# Altium schematic sheet backplane.SchDoc
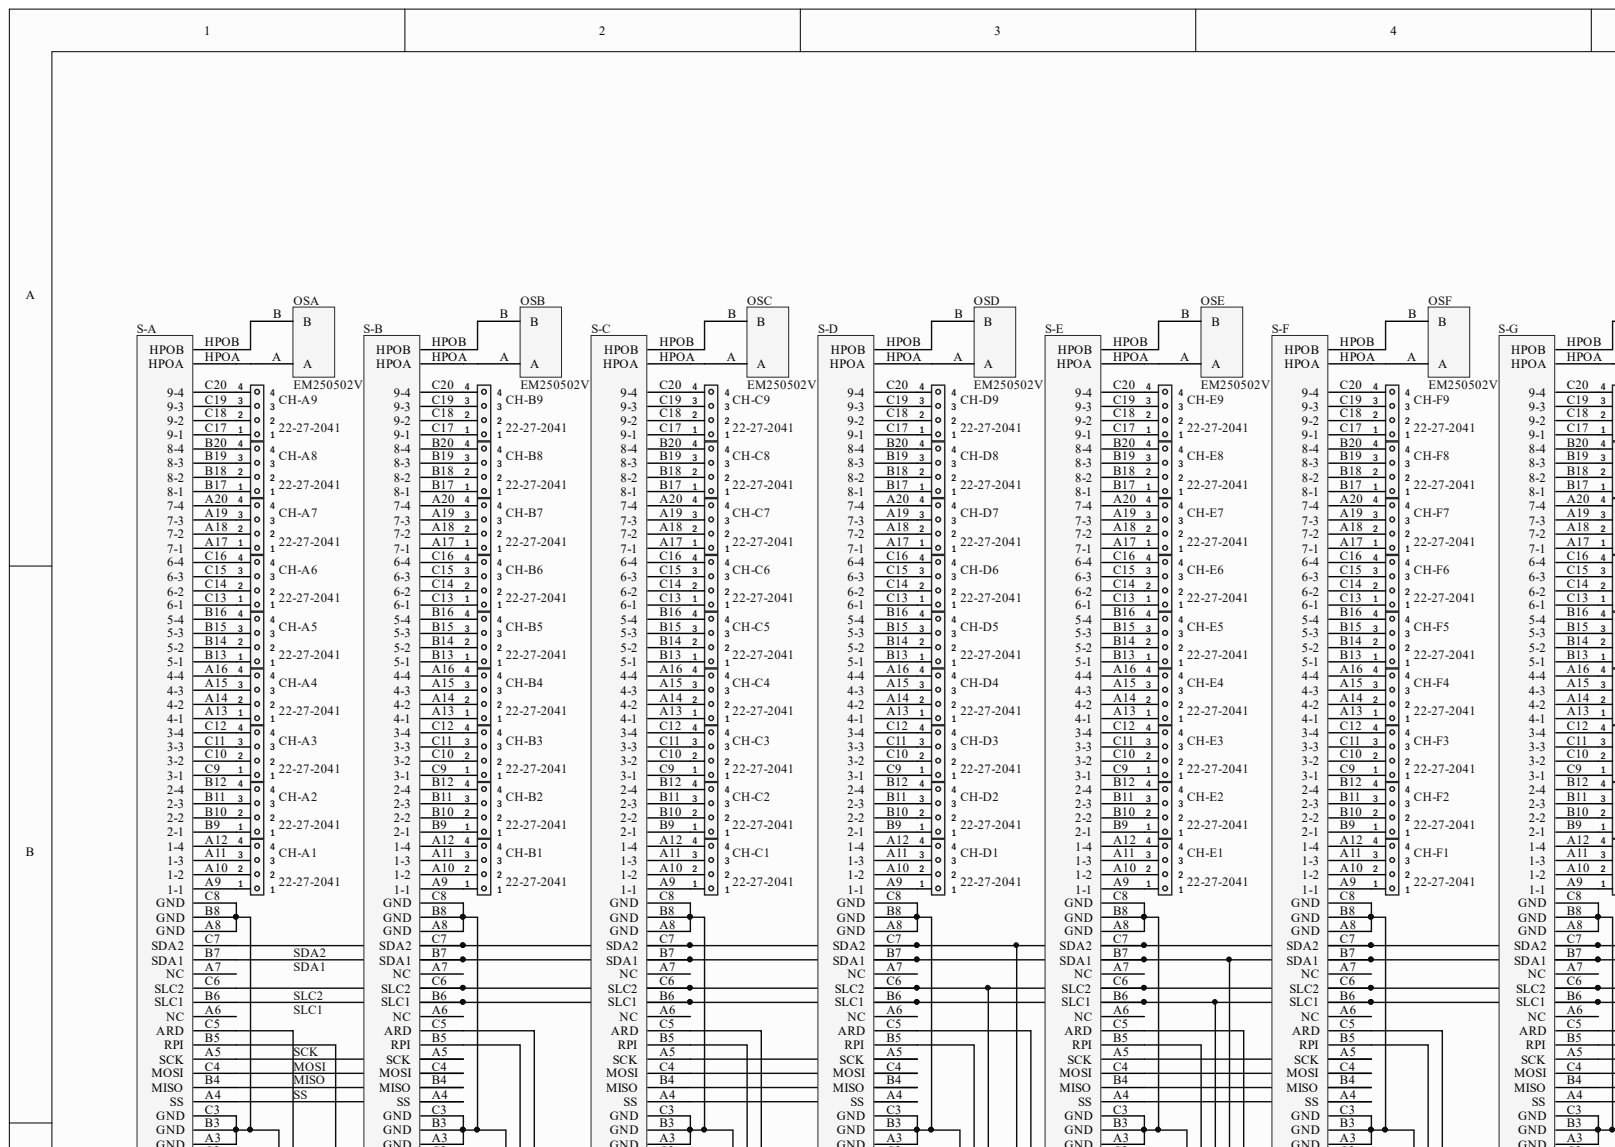
[diagram p0: backplane.SchDoc, top left]
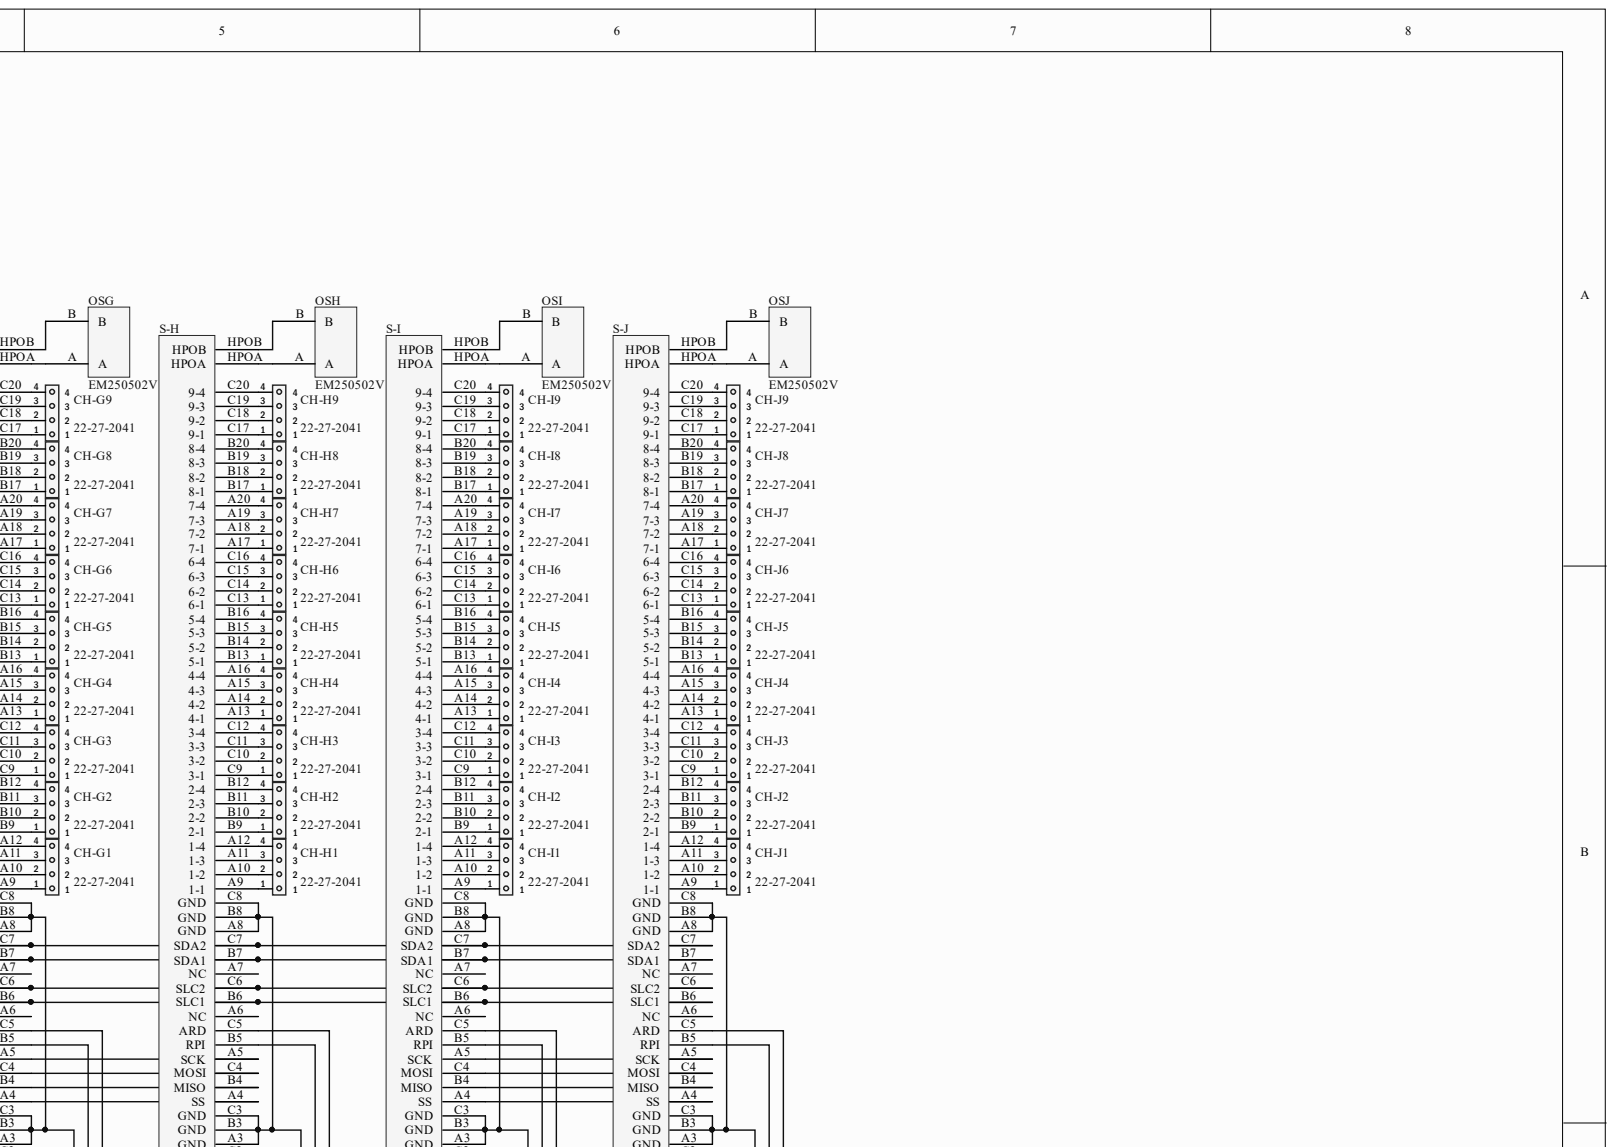
[diagram p1: backplane.SchDoc, top right]
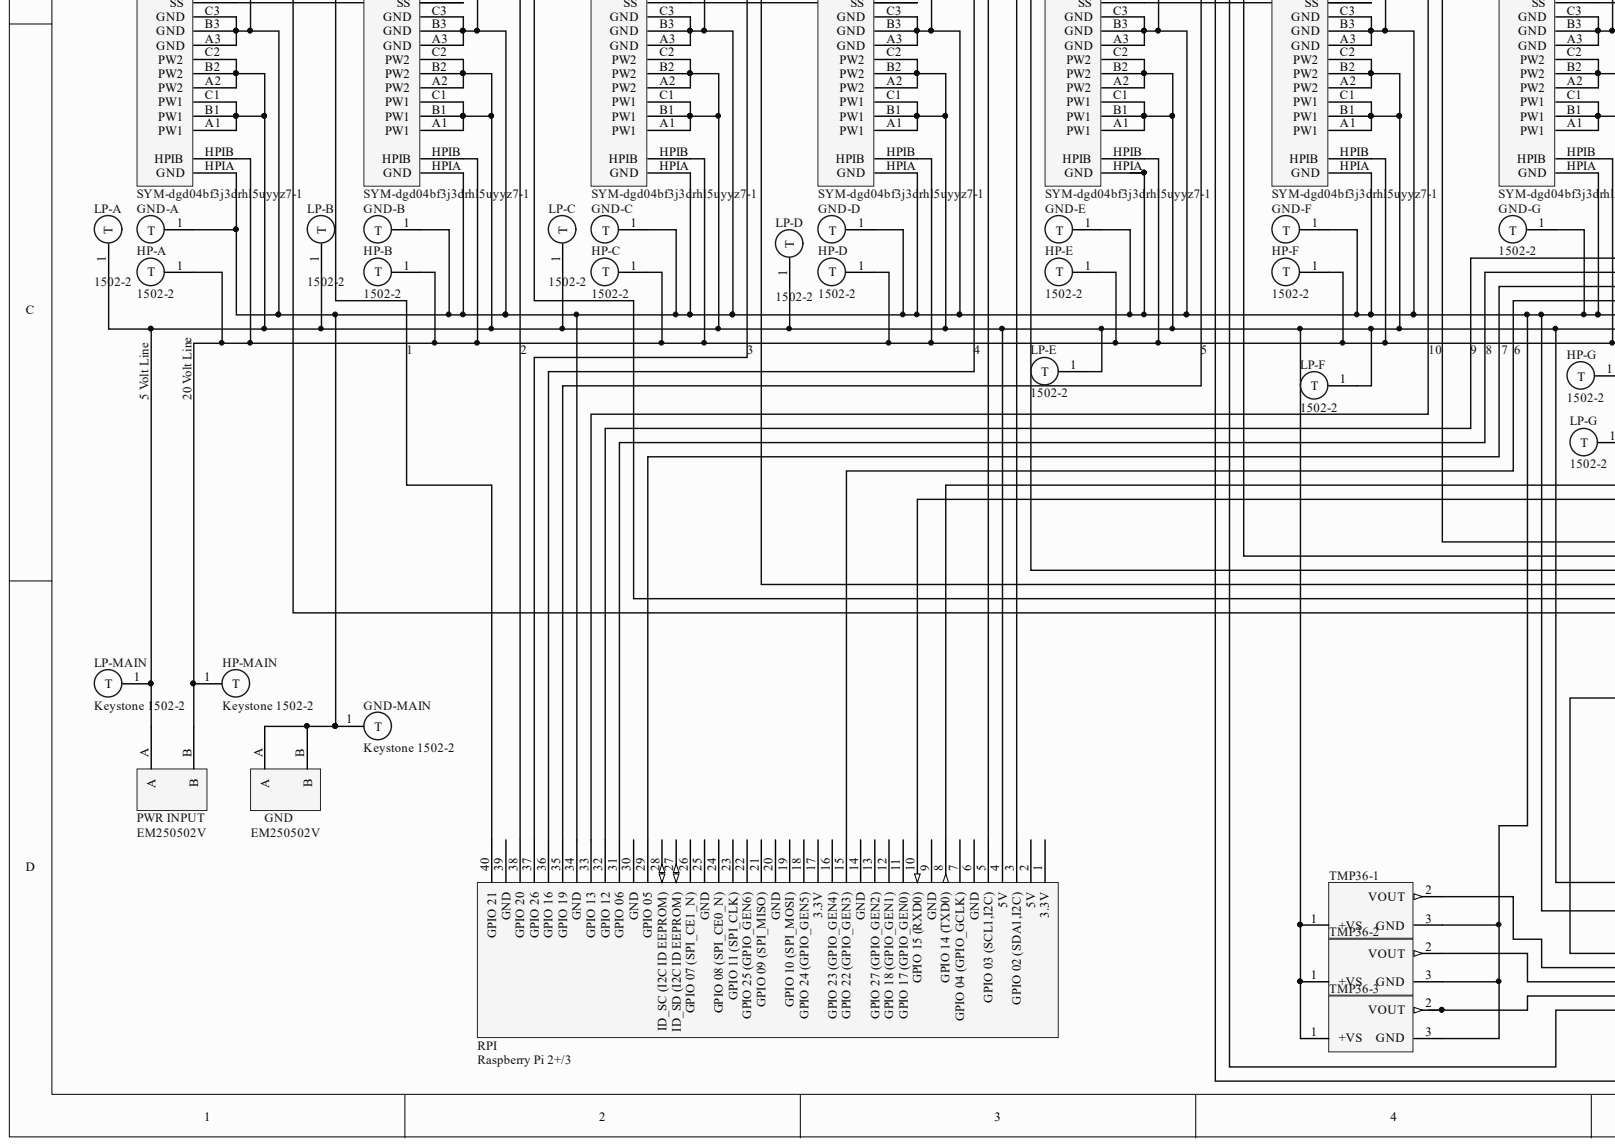
[diagram p2: backplane.SchDoc, bottom left]
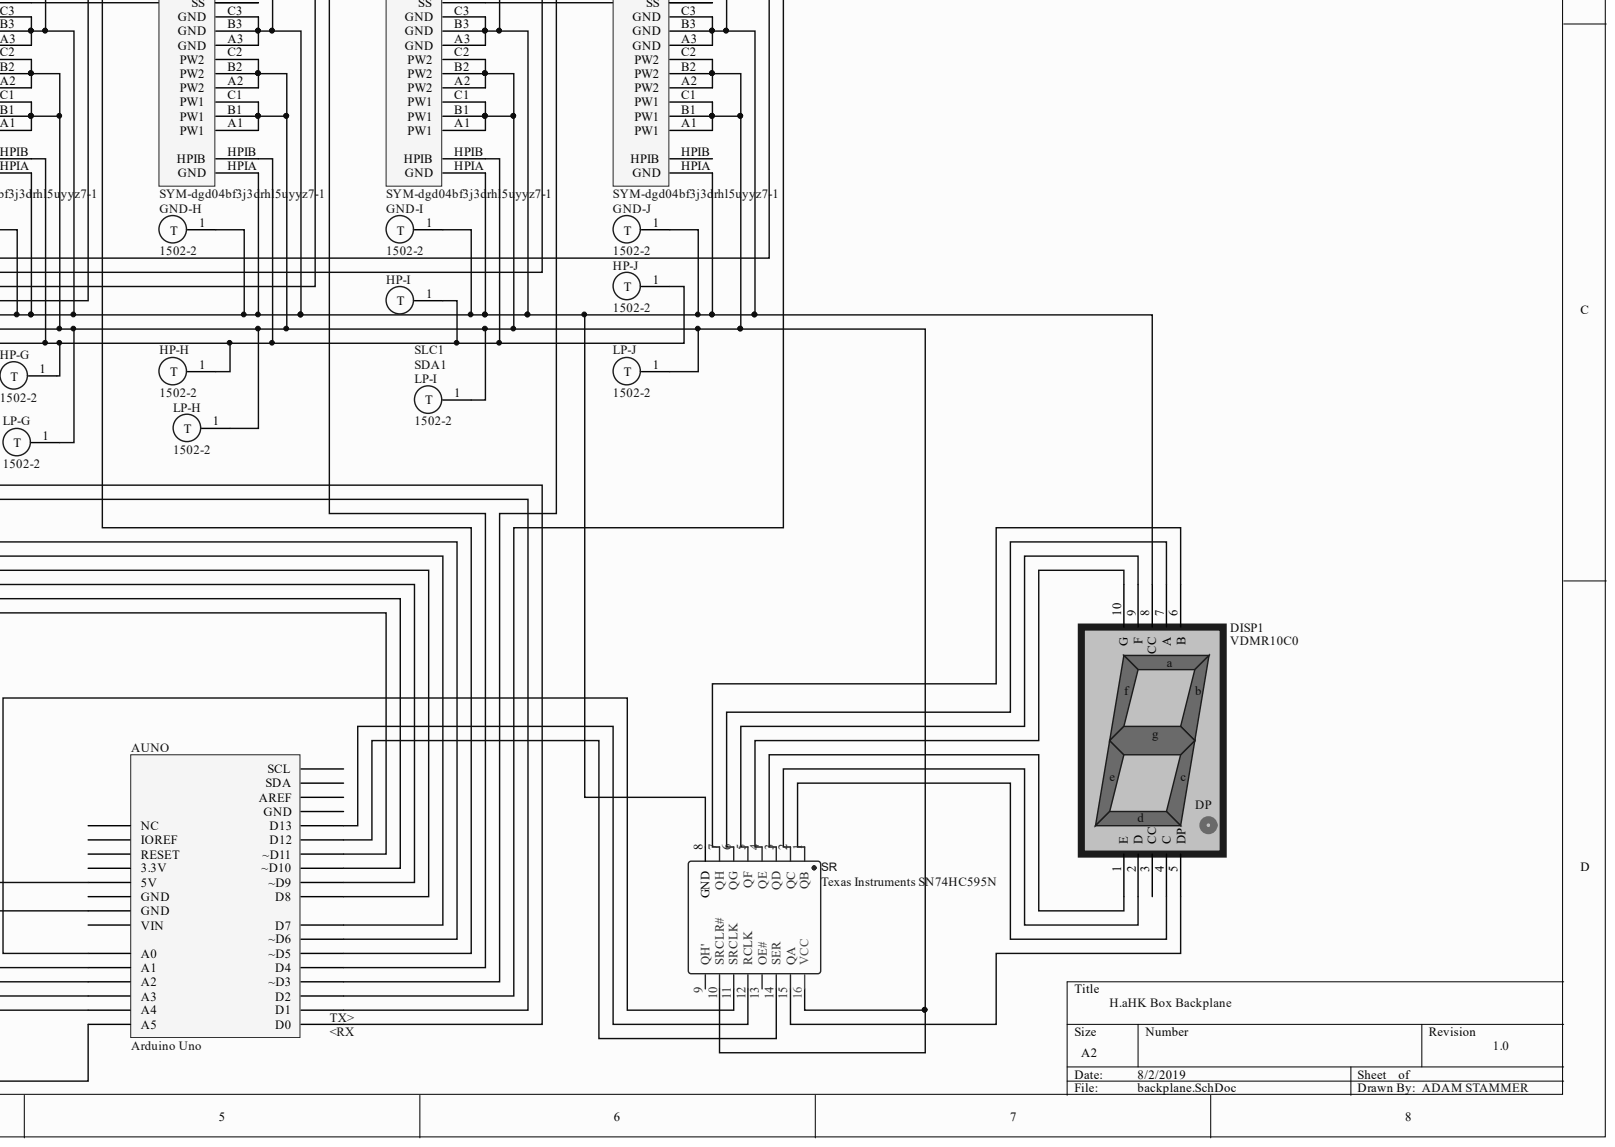
[diagram p3: backplane.SchDoc, bottom right]

## Parts
AUNO  Arduino Uno  [PCB-5e3n8s0ey5jqr2f9nncc-1]
CH-A1  22-27-2041  [PCB-kaiyohh0lgufhypq6v61-1]
CH-A2  22-27-2041  [PCB-kaiyohh0lgufhypq6v61-1]
CH-A3  22-27-2041  [PCB-kaiyohh0lgufhypq6v61-1]
CH-A4  22-27-2041  [PCB-kaiyohh0lgufhypq6v61-1]
CH-A5  22-27-2041  [PCB-kaiyohh0lgufhypq6v61-1]
CH-A6  22-27-2041  [PCB-kaiyohh0lgufhypq6v61-1]
CH-A7  22-27-2041  [PCB-kaiyohh0lgufhypq6v61-1]
CH-A8  22-27-2041  [PCB-kaiyohh0lgufhypq6v61-1]
CH-A9  22-27-2041  [PCB-kaiyohh0lgufhypq6v61-1]
CH-B1  22-27-2041  [PCB-kaiyohh0lgufhypq6v61-1]
CH-B2  22-27-2041  [PCB-kaiyohh0lgufhypq6v61-1]
CH-B3  22-27-2041  [PCB-kaiyohh0lgufhypq6v61-1]
CH-B4  22-27-2041  [PCB-kaiyohh0lgufhypq6v61-1]
CH-B5  22-27-2041  [PCB-kaiyohh0lgufhypq6v61-1]
CH-B6  22-27-2041  [PCB-kaiyohh0lgufhypq6v61-1]
CH-B7  22-27-2041  [PCB-kaiyohh0lgufhypq6v61-1]
CH-B8  22-27-2041  [PCB-kaiyohh0lgufhypq6v61-1]
CH-B9  22-27-2041  [PCB-kaiyohh0lgufhypq6v61-1]
CH-C1  22-27-2041  [PCB-kaiyohh0lgufhypq6v61-1]
CH-C2  22-27-2041  [PCB-kaiyohh0lgufhypq6v61-1]
CH-C3  22-27-2041  [PCB-kaiyohh0lgufhypq6v61-1]
CH-C4  22-27-2041  [PCB-kaiyohh0lgufhypq6v61-1]
CH-C5  22-27-2041  [PCB-kaiyohh0lgufhypq6v61-1]
CH-C6  22-27-2041  [PCB-kaiyohh0lgufhypq6v61-1]
CH-C7  22-27-2041  [PCB-kaiyohh0lgufhypq6v61-1]
CH-C8  22-27-2041  [PCB-kaiyohh0lgufhypq6v61-1]
CH-C9  22-27-2041  [PCB-kaiyohh0lgufhypq6v61-1]
CH-D1  22-27-2041  [PCB-kaiyohh0lgufhypq6v61-1]
CH-D2  22-27-2041  [PCB-kaiyohh0lgufhypq6v61-1]
CH-D3  22-27-2041  [PCB-kaiyohh0lgufhypq6v61-1]
CH-D4  22-27-2041  [PCB-kaiyohh0lgufhypq6v61-1]
CH-D5  22-27-2041  [PCB-kaiyohh0lgufhypq6v61-1]
CH-D6  22-27-2041  [PCB-kaiyohh0lgufhypq6v61-1]
CH-D7  22-27-2041  [PCB-kaiyohh0lgufhypq6v61-1]
CH-D8  22-27-2041  [PCB-kaiyohh0lgufhypq6v61-1]
CH-D9  22-27-2041  [PCB-kaiyohh0lgufhypq6v61-1]
CH-E1  22-27-2041  [PCB-kaiyohh0lgufhypq6v61-1]
CH-E2  22-27-2041  [PCB-kaiyohh0lgufhypq6v61-1]
CH-E3  22-27-2041  [PCB-kaiyohh0lgufhypq6v61-1]
CH-E4  22-27-2041  [PCB-kaiyohh0lgufhypq6v61-1]
CH-E5  22-27-2041  [PCB-kaiyohh0lgufhypq6v61-1]
CH-E6  22-27-2041  [PCB-kaiyohh0lgufhypq6v61-1]
CH-E7  22-27-2041  [PCB-kaiyohh0lgufhypq6v61-1]
CH-E8  22-27-2041  [PCB-kaiyohh0lgufhypq6v61-1]
CH-E9  22-27-2041  [PCB-kaiyohh0lgufhypq6v61-1]
CH-F1  22-27-2041  [PCB-kaiyohh0lgufhypq6v61-1]
CH-F2  22-27-2041  [PCB-kaiyohh0lgufhypq6v61-1]
CH-F3  22-27-2041  [PCB-kaiyohh0lgufhypq6v61-1]
CH-F4  22-27-2041  [PCB-kaiyohh0lgufhypq6v61-1]
CH-F5  22-27-2041  [PCB-kaiyohh0lgufhypq6v61-1]
CH-F6  22-27-2041  [PCB-kaiyohh0lgufhypq6v61-1]
CH-F7  22-27-2041  [PCB-kaiyohh0lgufhypq6v61-1]
CH-F8  22-27-2041  [PCB-kaiyohh0lgufhypq6v61-1]
CH-F9  22-27-2041  [PCB-kaiyohh0lgufhypq6v61-1]
CH-G1  22-27-2041  [PCB-kaiyohh0lgufhypq6v61-1]
CH-G2  22-27-2041  [PCB-kaiyohh0lgufhypq6v61-1]
CH-G3  22-27-2041  [PCB-kaiyohh0lgufhypq6v61-1]
CH-G4  22-27-2041  [PCB-kaiyohh0lgufhypq6v61-1]
CH-G5  22-27-2041  [PCB-kaiyohh0lgufhypq6v61-1]
CH-G6  22-27-2041  [PCB-kaiyohh0lgufhypq6v61-1]
CH-G7  22-27-2041  [PCB-kaiyohh0lgufhypq6v61-1]
CH-G8  22-27-2041  [PCB-kaiyohh0lgufhypq6v61-1]
CH-G9  22-27-2041  [PCB-kaiyohh0lgufhypq6v61-1]
CH-H1  22-27-2041  [PCB-kaiyohh0lgufhypq6v61-1]
CH-H2  22-27-2041  [PCB-kaiyohh0lgufhypq6v61-1]
CH-H3  22-27-2041  [PCB-kaiyohh0lgufhypq6v61-1]
CH-H4  22-27-2041  [PCB-kaiyohh0lgufhypq6v61-1]
CH-H5  22-27-2041  [PCB-kaiyohh0lgufhypq6v61-1]
CH-H6  22-27-2041  [PCB-kaiyohh0lgufhypq6v61-1]
CH-H7  22-27-2041  [PCB-kaiyohh0lgufhypq6v61-1]
CH-H8  22-27-2041  [PCB-kaiyohh0lgufhypq6v61-1]
CH-H9  22-27-2041  [PCB-kaiyohh0lgufhypq6v61-1]
CH-I1  22-27-2041  [PCB-kaiyohh0lgufhypq6v61-1]
CH-I2  22-27-2041  [PCB-kaiyohh0lgufhypq6v61-1]
CH-I3  22-27-2041  [PCB-kaiyohh0lgufhypq6v61-1]
CH-I4  22-27-2041  [PCB-kaiyohh0lgufhypq6v61-1]
CH-I5  22-27-2041  [PCB-kaiyohh0lgufhypq6v61-1]
CH-I6  22-27-2041  [PCB-kaiyohh0lgufhypq6v61-1]
CH-I7  22-27-2041  [PCB-kaiyohh0lgufhypq6v61-1]
CH-I8  22-27-2041  [PCB-kaiyohh0lgufhypq6v61-1]
CH-I9  22-27-2041  [PCB-kaiyohh0lgufhypq6v61-1]
CH-J1  22-27-2041  [PCB-kaiyohh0lgufhypq6v61-1]
CH-J2  22-27-2041  [PCB-kaiyohh0lgufhypq6v61-1]
CH-J3  22-27-2041  [PCB-kaiyohh0lgufhypq6v61-1]
CH-J4  22-27-2041  [PCB-kaiyohh0lgufhypq6v61-1]
CH-J5  22-27-2041  [PCB-kaiyohh0lgufhypq6v61-1]
CH-J6  22-27-2041  [PCB-kaiyohh0lgufhypq6v61-1]
CH-J7  22-27-2041  [PCB-kaiyohh0lgufhypq6v61-1]
CH-J8  22-27-2041  [PCB-kaiyohh0lgufhypq6v61-1]
CH-J9  22-27-2041  [PCB-kaiyohh0lgufhypq6v61-1]
DISP1  VDMR10C0  [PCBComponent_1 - duplicate]
GND  EM250502V  [PCB-u2v43o1ftwqs3duy389i-1]
GND-A  1502-2  [PCB-3pxxqie2fct8emy2m3uy-1]
GND-B  1502-2  [PCB-3pxxqie2fct8emy2m3uy-1]
GND-C  1502-2  [PCB-3pxxqie2fct8emy2m3uy-1]
GND-D  1502-2  [PCB-3pxxqie2fct8emy2m3uy-1]
GND-E  1502-2  [PCB-3pxxqie2fct8emy2m3uy-1]
GND-F  1502-2  [PCB-3pxxqie2fct8emy2m3uy-1]
GND-G  1502-2  [PCB-3pxxqie2fct8emy2m3uy-1]
GND-H  1502-2  [PCB-3pxxqie2fct8emy2m3uy-1]
GND-I  1502-2  [PCB-3pxxqie2fct8emy2m3uy-1]
GND-J  1502-2  [PCB-3pxxqie2fct8emy2m3uy-1]
GND-MAIN  Keystone 1502-2  Manufacturer Part Number: 1502-2  [PCB-3pxxqie2fct8emy2m3uy-1]
HP-A  1502-2  [PCB-3pxxqie2fct8emy2m3uy-1]
HP-B  1502-2  [PCB-3pxxqie2fct8emy2m3uy-1]
HP-C  1502-2  [PCB-3pxxqie2fct8emy2m3uy-1]
HP-D  1502-2  [PCB-3pxxqie2fct8emy2m3uy-1]
HP-E  1502-2  [PCB-3pxxqie2fct8emy2m3uy-1]
HP-F  1502-2  [PCB-3pxxqie2fct8emy2m3uy-1]
HP-G  1502-2  [PCB-3pxxqie2fct8emy2m3uy-1]
HP-H  1502-2  [PCB-3pxxqie2fct8emy2m3uy-1]
HP-I  1502-2  [PCB-3pxxqie2fct8emy2m3uy-1]
HP-J  1502-2  [PCB-3pxxqie2fct8emy2m3uy-1]
HP-MAIN  Keystone 1502-2  Manufacturer Part Number: 1502-2  [PCB-3pxxqie2fct8emy2m3uy-1]
LP-A  1502-2  [PCB-3pxxqie2fct8emy2m3uy-1]
LP-B  1502-2  [PCB-3pxxqie2fct8emy2m3uy-1]
LP-C  1502-2  [PCB-3pxxqie2fct8emy2m3uy-1]
LP-D  1502-2  [PCB-3pxxqie2fct8emy2m3uy-1]
LP-E  1502-2  [PCB-3pxxqie2fct8emy2m3uy-1]
LP-F  1502-2  [PCB-3pxxqie2fct8emy2m3uy-1]
LP-G  1502-2  [PCB-3pxxqie2fct8emy2m3uy-1]
LP-H  1502-2  [PCB-3pxxqie2fct8emy2m3uy-1]
LP-I  1502-2  [PCB-3pxxqie2fct8emy2m3uy-1]
LP-J  1502-2  [PCB-3pxxqie2fct8emy2m3uy-1]
LP-MAIN  Keystone 1502-2  Manufacturer Part Number: 1502-2  [PCB-3pxxqie2fct8emy2m3uy-1]
OSA  EM250502V  [PCB-u2v43o1ftwqs3duy389i-1]
OSB  EM250502V  [PCB-u2v43o1ftwqs3duy389i-1]
OSC  EM250502V  [PCB-u2v43o1ftwqs3duy389i-1]
OSD  EM250502V  [PCB-u2v43o1ftwqs3duy389i-1]
OSE  EM250502V  [PCB-u2v43o1ftwqs3duy389i-1]
OSF  EM250502V  [PCB-u2v43o1ftwqs3duy389i-1]
OSG  EM250502V  [PCB-u2v43o1ftwqs3duy389i-1]
OSH  EM250502V  [PCB-u2v43o1ftwqs3duy389i-1]
OSI  EM250502V  [PCB-u2v43o1ftwqs3duy389i-1]
OSJ  EM250502V  [PCB-u2v43o1ftwqs3duy389i-1]
PWR_INPUT  EM250502V  [PCB-u2v43o1ftwqs3duy389i-1]
RPI  Raspberry Pi 2+/3  [Header Only]
S-A  SYM-dgd04bf3j3drhl5uyyz7-1  [PCBComponent_1 - duplicate]
S-B  SYM-dgd04bf3j3drhl5uyyz7-1  [PCBComponent_1 - duplicate]
S-C  SYM-dgd04bf3j3drhl5uyyz7-1  [PCBComponent_1 - duplicate]
S-D  SYM-dgd04bf3j3drhl5uyyz7-1  [PCBComponent_1 - duplicate]
S-E  SYM-dgd04bf3j3drhl5uyyz7-1  [PCBComponent_1 - duplicate]
S-F  SYM-dgd04bf3j3drhl5uyyz7-1  [PCBComponent_1 - duplicate]
S-G  SYM-dgd04bf3j3drhl5uyyz7-1  [PCBComponent_1 - duplicate]
S-H  SYM-dgd04bf3j3drhl5uyyz7-1  [PCBComponent_1 - duplicate]
S-I  SYM-dgd04bf3j3drhl5uyyz7-1  [PCBComponent_1 - duplicate]
S-J  SYM-dgd04bf3j3drhl5uyyz7-1  [PCBComponent_1 - duplicate]
SR  Texas Instruments SN74HC595N
TMP36-1  TMP36GT9  [ADI-T-3]  (value not drawn)
TMP36-2  TMP36GT9  [ADI-T-3]  (value not drawn)
TMP36-3  TMP36GT9  [ADI-T-3]  (value not drawn)

## Nets
NetAUNO_5V: AUNO.5V LP-A.1 LP-B.1 LP-C.1 LP-D.1 LP-E.1 LP-F.1 LP-G.1 LP-H.1 LP-I.1 LP-J.1 LP-MAIN.1 PWR_INPUT.A RPI.4(5V) S-A.A1(PW1) S-A.A2(PW2) S-A.B1(PW1) S-A.B2(PW2) S-A.C1(PW1) S-A.C2(PW2) S-B.A1(PW1) S-B.A2(PW2) S-B.B1(PW1) S-B.B2(PW2) S-B.C1(PW1) S-B.C2(PW2) S-C.A1(PW1) S-C.A2(PW2) S-C.B1(PW1) S-C.B2(PW2) S-C.C1(PW1) S-C.C2(PW2) S-D.A1(PW1) S-D.A2(PW2) S-D.B1(PW1) S-D.B2(PW2) S-D.C1(PW1) S-D.C2(PW2) S-E.A1(PW1) S-E.A2(PW2) S-E.B1(PW1) S-E.B2(PW2) S-E.C1(PW1) S-E.C2(PW2) S-F.A1(PW1) S-F.A2(PW2) S-F.B1(PW1) S-F.B2(PW2) S-F.C1(PW1) S-F.C2(PW2) S-G.A1(PW1) S-G.A2(PW2) S-G.B1(PW1) S-G.B2(PW2) S-G.C1(PW1) S-G.C2(PW2) S-H.A1(PW1) S-H.A2(PW2) S-H.B1(PW1) S-H.B2(PW2) S-H.C1(PW1) S-H.C2(PW2) S-I.A1(PW1) S-I.A2(PW2) S-I.B1(PW1) S-I.B2(PW2) S-I.C1(PW1) S-I.C2(PW2) S-J.A1(PW1) S-J.A2(PW2) S-J.B1(PW1) S-J.B2(PW2) S-J.C1(PW1) S-J.C2(PW2) SR.10(SRCLR#) SR.16(VCC) TMP36-1.1 TMP36-2.1 TMP36-3.1
NetAUNO_A0: AUNO.A0 SR.11(SRCLK)
NetAUNO_A1: AUNO.A1 TMP36-1.2
NetAUNO_A2: AUNO.A2 TMP36-2.2
NetAUNO_A3: AUNO.A3 TMP36-3.2
NetAUNO_A4: AUNO.A4 S-A.B7(SDA1) S-B.B7(SDA1) S-C.B7(SDA1) S-D.B7(SDA1) S-E.B7(SDA1) S-F.B7(SDA1) S-G.B7(SDA1) S-H.B7(SDA1) S-I.B7(SDA1) S-J.B7(SDA1)
NetAUNO_A5: AUNO.A5 S-A.B6(SLC1) S-B.B6(SLC1) S-C.B6(SLC1) S-D.B6(SLC1) S-E.B6(SLC1) S-F.B6(SLC1) S-G.B6(SLC1) S-H.B6(SLC1) S-I.B6(SLC1) S-J.B6(SLC1)
NetAUNO_D0: AUNO.D0 RPI.8(GPIO_14_(TXD0))
NetAUNO_D1: AUNO.D1 RPI.10(GPIO_15_(RXD0))
NetAUNO_D2: AUNO.D2 S-J.C5(ARD)
NetAUNO_D3: AUNO.D3(~D3) S-I.C5(ARD)
NetAUNO_D4: AUNO.D4 S-H.C5(ARD)
NetAUNO_D5: AUNO.D5(~D5) S-G.C5(ARD)
NetAUNO_D6: AUNO.D6(~D6) S-F.C5(ARD)
NetAUNO_D7: AUNO.D7 S-E.C5(ARD)
NetAUNO_D8: AUNO.D8 S-D.C5(ARD)
NetAUNO_D9: AUNO.D9(~D9) S-C.C5(ARD)
NetAUNO_D10: AUNO.D10(~D10) S-B.C5(ARD)
NetAUNO_D11: AUNO.D11(~D11) S-A.C5(ARD)
NetAUNO_D12: AUNO.D12 SR.14(SER)
NetAUNO_D13: AUNO.D13 SR.12(RCLK)
NetAUNO_GND2: AUNO.GND2(GND) DISP1.8(CC) GND.A GND.B GND-A.1 GND-B.1 GND-C.1 GND-D.1 GND-E.1 GND-F.1 GND-G.1 GND-H.1 GND-I.1 GND-J.1 GND-MAIN.1 RPI.34(GND) S-A.A3(GND) S-A.A8(GND) S-A.B3(GND) S-A.B8(GND) S-A.C3(GND) S-A.C8(GND) S-A.HPIA(GND) S-B.A3(GND) S-B.A8(GND) S-B.B3(GND) S-B.B8(GND) S-B.C3(GND) S-B.C8(GND) S-B.HPIA(GND) S-C.A3(GND) S-C.A8(GND) S-C.B3(GND) S-C.B8(GND) S-C.C3(GND) S-C.C8(GND) S-C.HPIA(GND) S-D.A3(GND) S-D.A8(GND) S-D.B3(GND) S-D.B8(GND) S-D.C3(GND) S-D.C8(GND) S-D.HPIA(GND) S-E.A3(GND) S-E.A8(GND) S-E.B3(GND) S-E.B8(GND) S-E.C3(GND) S-E.C8(GND) S-E.HPIA(GND) S-F.A3(GND) S-F.A8(GND) S-F.B3(GND) S-F.B8(GND) S-F.C3(GND) S-F.C8(GND) S-F.HPIA(GND) S-G.A3(GND) S-G.A8(GND) S-G.B3(GND) S-G.B8(GND) S-G.C3(GND) S-G.C8(GND) S-G.HPIA(GND) S-H.A3(GND) S-H.A8(GND) S-H.B3(GND) S-H.B8(GND) S-H.C3(GND) S-H.C8(GND) S-H.HPIA(GND) S-I.A3(GND) S-I.A8(GND) S-I.B3(GND) S-I.B8(GND) S-I.C3(GND) S-I.C8(GND) S-I.HPIA(GND) S-J.A3(GND) S-J.A8(GND) S-J.B3(GND) S-J.B8(GND) S-J.C3(GND) S-J.C8(GND) S-J.HPIA(GND) SR.8(GND) TMP36-1.3 TMP36-2.3 TMP36-3.3
NetCH-A1_1: CH-A1.1 S-A.A9(1-1)
NetCH-A1_2: CH-A1.2 S-A.A10(1-2)
NetCH-A1_3: CH-A1.3 S-A.A11(1-3)
NetCH-A1_4: CH-A1.4 S-A.A12(1-4)
NetCH-A2_1: CH-A2.1 S-A.B9(2-1)
NetCH-A2_2: CH-A2.2 S-A.B10(2-2)
NetCH-A2_3: CH-A2.3 S-A.B11(2-3)
NetCH-A2_4: CH-A2.4 S-A.B12(2-4)
NetCH-A3_1: CH-A3.1 S-A.C9(3-1)
NetCH-A3_2: CH-A3.2 S-A.C10(3-2)
NetCH-A3_3: CH-A3.3 S-A.C11(3-3)
NetCH-A3_4: CH-A3.4 S-A.C12(3-4)
NetCH-A4_1: CH-A4.1 S-A.A13(4-1)
NetCH-A4_2: CH-A4.2 S-A.A14(4-2)
NetCH-A4_3: CH-A4.3 S-A.A15(4-3)
NetCH-A4_4: CH-A4.4 S-A.A16(4-4)
NetCH-A5_1: CH-A5.1 S-A.B13(5-1)
NetCH-A5_2: CH-A5.2 S-A.B14(5-2)
NetCH-A5_3: CH-A5.3 S-A.B15(5-3)
NetCH-A5_4: CH-A5.4 S-A.B16(5-4)
NetCH-A6_1: CH-A6.1 S-A.C13(6-1)
NetCH-A6_2: CH-A6.2 S-A.C14(6-2)
NetCH-A6_3: CH-A6.3 S-A.C15(6-3)
NetCH-A6_4: CH-A6.4 S-A.C16(6-4)
NetCH-A7_1: CH-A7.1 S-A.A17(7-1)
NetCH-A7_2: CH-A7.2 S-A.A18(7-2)
NetCH-A7_3: CH-A7.3 S-A.A19(7-3)
NetCH-A7_4: CH-A7.4 S-A.A20(7-4)
NetCH-A8_1: CH-A8.1 S-A.B17(8-1)
NetCH-A8_2: CH-A8.2 S-A.B18(8-2)
NetCH-A8_3: CH-A8.3 S-A.B19(8-3)
NetCH-A8_4: CH-A8.4 S-A.B20(8-4)
NetCH-A9_1: CH-A9.1 S-A.C17(9-1)
NetCH-A9_2: CH-A9.2 S-A.C18(9-2)
NetCH-A9_3: CH-A9.3 S-A.C19(9-3)
NetCH-A9_4: CH-A9.4 S-A.C20(9-4)
NetCH-B1_1: CH-B1.1 S-B.A9(1-1)
NetCH-B1_2: CH-B1.2 S-B.A10(1-2)
NetCH-B1_3: CH-B1.3 S-B.A11(1-3)
NetCH-B1_4: CH-B1.4 S-B.A12(1-4)
NetCH-B2_1: CH-B2.1 S-B.B9(2-1)
NetCH-B2_2: CH-B2.2 S-B.B10(2-2)
NetCH-B2_3: CH-B2.3 S-B.B11(2-3)
NetCH-B2_4: CH-B2.4 S-B.B12(2-4)
NetCH-B3_1: CH-B3.1 S-B.C9(3-1)
NetCH-B3_2: CH-B3.2 S-B.C10(3-2)
NetCH-B3_3: CH-B3.3 S-B.C11(3-3)
NetCH-B3_4: CH-B3.4 S-B.C12(3-4)
NetCH-B4_1: CH-B4.1 S-B.A13(4-1)
NetCH-B4_2: CH-B4.2 S-B.A14(4-2)
NetCH-B4_3: CH-B4.3 S-B.A15(4-3)
NetCH-B4_4: CH-B4.4 S-B.A16(4-4)
NetCH-B5_1: CH-B5.1 S-B.B13(5-1)
NetCH-B5_2: CH-B5.2 S-B.B14(5-2)
NetCH-B5_3: CH-B5.3 S-B.B15(5-3)
NetCH-B5_4: CH-B5.4 S-B.B16(5-4)
NetCH-B6_1: CH-B6.1 S-B.C13(6-1)
NetCH-B6_2: CH-B6.2 S-B.C14(6-2)
NetCH-B6_3: CH-B6.3 S-B.C15(6-3)
NetCH-B6_4: CH-B6.4 S-B.C16(6-4)
NetCH-B7_1: CH-B7.1 S-B.A17(7-1)
NetCH-B7_2: CH-B7.2 S-B.A18(7-2)
NetCH-B7_3: CH-B7.3 S-B.A19(7-3)
NetCH-B7_4: CH-B7.4 S-B.A20(7-4)
NetCH-B8_1: CH-B8.1 S-B.B17(8-1)
NetCH-B8_2: CH-B8.2 S-B.B18(8-2)
NetCH-B8_3: CH-B8.3 S-B.B19(8-3)
NetCH-B8_4: CH-B8.4 S-B.B20(8-4)
NetCH-B9_1: CH-B9.1 S-B.C17(9-1)
NetCH-B9_2: CH-B9.2 S-B.C18(9-2)
NetCH-B9_3: CH-B9.3 S-B.C19(9-3)
NetCH-B9_4: CH-B9.4 S-B.C20(9-4)
NetCH-C1_1: CH-C1.1 S-C.A9(1-1)
NetCH-C1_2: CH-C1.2 S-C.A10(1-2)
NetCH-C1_3: CH-C1.3 S-C.A11(1-3)
NetCH-C1_4: CH-C1.4 S-C.A12(1-4)
NetCH-C2_1: CH-C2.1 S-C.B9(2-1)
NetCH-C2_2: CH-C2.2 S-C.B10(2-2)
NetCH-C2_3: CH-C2.3 S-C.B11(2-3)
NetCH-C2_4: CH-C2.4 S-C.B12(2-4)
NetCH-C3_1: CH-C3.1 S-C.C9(3-1)
NetCH-C3_2: CH-C3.2 S-C.C10(3-2)
NetCH-C3_3: CH-C3.3 S-C.C11(3-3)
NetCH-C3_4: CH-C3.4 S-C.C12(3-4)
NetCH-C4_1: CH-C4.1 S-C.A13(4-1)
NetCH-C4_2: CH-C4.2 S-C.A14(4-2)
NetCH-C4_3: CH-C4.3 S-C.A15(4-3)
NetCH-C4_4: CH-C4.4 S-C.A16(4-4)
NetCH-C5_1: CH-C5.1 S-C.B13(5-1)
NetCH-C5_2: CH-C5.2 S-C.B14(5-2)
NetCH-C5_3: CH-C5.3 S-C.B15(5-3)
NetCH-C5_4: CH-C5.4 S-C.B16(5-4)
NetCH-C6_1: CH-C6.1 S-C.C13(6-1)
NetCH-C6_2: CH-C6.2 S-C.C14(6-2)
NetCH-C6_3: CH-C6.3 S-C.C15(6-3)
NetCH-C6_4: CH-C6.4 S-C.C16(6-4)
NetCH-C7_1: CH-C7.1 S-C.A17(7-1)
NetCH-C7_2: CH-C7.2 S-C.A18(7-2)
NetCH-C7_3: CH-C7.3 S-C.A19(7-3)
NetCH-C7_4: CH-C7.4 S-C.A20(7-4)
NetCH-C8_1: CH-C8.1 S-C.B17(8-1)
NetCH-C8_2: CH-C8.2 S-C.B18(8-2)
NetCH-C8_3: CH-C8.3 S-C.B19(8-3)
NetCH-C8_4: CH-C8.4 S-C.B20(8-4)
NetCH-C9_1: CH-C9.1 S-C.C17(9-1)
NetCH-C9_2: CH-C9.2 S-C.C18(9-2)
NetCH-C9_3: CH-C9.3 S-C.C19(9-3)
NetCH-C9_4: CH-C9.4 S-C.C20(9-4)
NetCH-D1_1: CH-D1.1 S-D.A9(1-1)
NetCH-D1_2: CH-D1.2 S-D.A10(1-2)
NetCH-D1_3: CH-D1.3 S-D.A11(1-3)
NetCH-D1_4: CH-D1.4 S-D.A12(1-4)
NetCH-D2_1: CH-D2.1 S-D.B9(2-1)
NetCH-D2_2: CH-D2.2 S-D.B10(2-2)
NetCH-D2_3: CH-D2.3 S-D.B11(2-3)
NetCH-D2_4: CH-D2.4 S-D.B12(2-4)
NetCH-D3_1: CH-D3.1 S-D.C9(3-1)
NetCH-D3_2: CH-D3.2 S-D.C10(3-2)
NetCH-D3_3: CH-D3.3 S-D.C11(3-3)
NetCH-D3_4: CH-D3.4 S-D.C12(3-4)
NetCH-D4_1: CH-D4.1 S-D.A13(4-1)
NetCH-D4_2: CH-D4.2 S-D.A14(4-2)
NetCH-D4_3: CH-D4.3 S-D.A15(4-3)
NetCH-D4_4: CH-D4.4 S-D.A16(4-4)
NetCH-D5_1: CH-D5.1 S-D.B13(5-1)
NetCH-D5_2: CH-D5.2 S-D.B14(5-2)
NetCH-D5_3: CH-D5.3 S-D.B15(5-3)
NetCH-D5_4: CH-D5.4 S-D.B16(5-4)
NetCH-D6_1: CH-D6.1 S-D.C13(6-1)
NetCH-D6_2: CH-D6.2 S-D.C14(6-2)
NetCH-D6_3: CH-D6.3 S-D.C15(6-3)
NetCH-D6_4: CH-D6.4 S-D.C16(6-4)
NetCH-D7_1: CH-D7.1 S-D.A17(7-1)
NetCH-D7_2: CH-D7.2 S-D.A18(7-2)
NetCH-D7_3: CH-D7.3 S-D.A19(7-3)
NetCH-D7_4: CH-D7.4 S-D.A20(7-4)
NetCH-D8_1: CH-D8.1 S-D.B17(8-1)
NetCH-D8_2: CH-D8.2 S-D.B18(8-2)
NetCH-D8_3: CH-D8.3 S-D.B19(8-3)
NetCH-D8_4: CH-D8.4 S-D.B20(8-4)
NetCH-D9_1: CH-D9.1 S-D.C17(9-1)
NetCH-D9_2: CH-D9.2 S-D.C18(9-2)
NetCH-D9_3: CH-D9.3 S-D.C19(9-3)
NetCH-D9_4: CH-D9.4 S-D.C20(9-4)
NetCH-E1_1: CH-E1.1 S-E.A9(1-1)
NetCH-E1_2: CH-E1.2 S-E.A10(1-2)
NetCH-E1_3: CH-E1.3 S-E.A11(1-3)
NetCH-E1_4: CH-E1.4 S-E.A12(1-4)
NetCH-E2_1: CH-E2.1 S-E.B9(2-1)
NetCH-E2_2: CH-E2.2 S-E.B10(2-2)
NetCH-E2_3: CH-E2.3 S-E.B11(2-3)
NetCH-E2_4: CH-E2.4 S-E.B12(2-4)
NetCH-E3_1: CH-E3.1 S-E.C9(3-1)
NetCH-E3_2: CH-E3.2 S-E.C10(3-2)
NetCH-E3_3: CH-E3.3 S-E.C11(3-3)
NetCH-E3_4: CH-E3.4 S-E.C12(3-4)
NetCH-E4_1: CH-E4.1 S-E.A13(4-1)
NetCH-E4_2: CH-E4.2 S-E.A14(4-2)
NetCH-E4_3: CH-E4.3 S-E.A15(4-3)
NetCH-E4_4: CH-E4.4 S-E.A16(4-4)
NetCH-E5_1: CH-E5.1 S-E.B13(5-1)
NetCH-E5_2: CH-E5.2 S-E.B14(5-2)
NetCH-E5_3: CH-E5.3 S-E.B15(5-3)
NetCH-E5_4: CH-E5.4 S-E.B16(5-4)
NetCH-E6_1: CH-E6.1 S-E.C13(6-1)
NetCH-E6_2: CH-E6.2 S-E.C14(6-2)
NetCH-E6_3: CH-E6.3 S-E.C15(6-3)
NetCH-E6_4: CH-E6.4 S-E.C16(6-4)
NetCH-E7_1: CH-E7.1 S-E.A17(7-1)
NetCH-E7_2: CH-E7.2 S-E.A18(7-2)
NetCH-E7_3: CH-E7.3 S-E.A19(7-3)
NetCH-E7_4: CH-E7.4 S-E.A20(7-4)
NetCH-E8_1: CH-E8.1 S-E.B17(8-1)
NetCH-E8_2: CH-E8.2 S-E.B18(8-2)
NetCH-E8_3: CH-E8.3 S-E.B19(8-3)
NetCH-E8_4: CH-E8.4 S-E.B20(8-4)
NetCH-E9_1: CH-E9.1 S-E.C17(9-1)
NetCH-E9_2: CH-E9.2 S-E.C18(9-2)
NetCH-E9_3: CH-E9.3 S-E.C19(9-3)
NetCH-E9_4: CH-E9.4 S-E.C20(9-4)
NetCH-F1_1: CH-F1.1 S-F.A9(1-1)
NetCH-F1_2: CH-F1.2 S-F.A10(1-2)
NetCH-F1_3: CH-F1.3 S-F.A11(1-3)
NetCH-F1_4: CH-F1.4 S-F.A12(1-4)
NetCH-F2_1: CH-F2.1 S-F.B9(2-1)
NetCH-F2_2: CH-F2.2 S-F.B10(2-2)
NetCH-F2_3: CH-F2.3 S-F.B11(2-3)
NetCH-F2_4: CH-F2.4 S-F.B12(2-4)
NetCH-F3_1: CH-F3.1 S-F.C9(3-1)
NetCH-F3_2: CH-F3.2 S-F.C10(3-2)
NetCH-F3_3: CH-F3.3 S-F.C11(3-3)
NetCH-F3_4: CH-F3.4 S-F.C12(3-4)
NetCH-F4_1: CH-F4.1 S-F.A13(4-1)
NetCH-F4_2: CH-F4.2 S-F.A14(4-2)
NetCH-F4_3: CH-F4.3 S-F.A15(4-3)
NetCH-F4_4: CH-F4.4 S-F.A16(4-4)
NetCH-F5_1: CH-F5.1 S-F.B13(5-1)
NetCH-F5_2: CH-F5.2 S-F.B14(5-2)
NetCH-F5_3: CH-F5.3 S-F.B15(5-3)
NetCH-F5_4: CH-F5.4 S-F.B16(5-4)
NetCH-F6_1: CH-F6.1 S-F.C13(6-1)
NetCH-F6_2: CH-F6.2 S-F.C14(6-2)
NetCH-F6_3: CH-F6.3 S-F.C15(6-3)
NetCH-F6_4: CH-F6.4 S-F.C16(6-4)
NetCH-F7_1: CH-F7.1 S-F.A17(7-1)
NetCH-F7_2: CH-F7.2 S-F.A18(7-2)
NetCH-F7_3: CH-F7.3 S-F.A19(7-3)
NetCH-F7_4: CH-F7.4 S-F.A20(7-4)
NetCH-F8_1: CH-F8.1 S-F.B17(8-1)
NetCH-F8_2: CH-F8.2 S-F.B18(8-2)
NetCH-F8_3: CH-F8.3 S-F.B19(8-3)
NetCH-F8_4: CH-F8.4 S-F.B20(8-4)
NetCH-F9_1: CH-F9.1 S-F.C17(9-1)
NetCH-F9_2: CH-F9.2 S-F.C18(9-2)
NetCH-F9_3: CH-F9.3 S-F.C19(9-3)
NetCH-F9_4: CH-F9.4 S-F.C20(9-4)
NetCH-G1_1: CH-G1.1 S-G.A9(1-1)
NetCH-G1_2: CH-G1.2 S-G.A10(1-2)
NetCH-G1_3: CH-G1.3 S-G.A11(1-3)
NetCH-G1_4: CH-G1.4 S-G.A12(1-4)
NetCH-G2_1: CH-G2.1 S-G.B9(2-1)
NetCH-G2_2: CH-G2.2 S-G.B10(2-2)
NetCH-G2_3: CH-G2.3 S-G.B11(2-3)
NetCH-G2_4: CH-G2.4 S-G.B12(2-4)
NetCH-G3_1: CH-G3.1 S-G.C9(3-1)
NetCH-G3_2: CH-G3.2 S-G.C10(3-2)
NetCH-G3_3: CH-G3.3 S-G.C11(3-3)
NetCH-G3_4: CH-G3.4 S-G.C12(3-4)
NetCH-G4_1: CH-G4.1 S-G.A13(4-1)
NetCH-G4_2: CH-G4.2 S-G.A14(4-2)
NetCH-G4_3: CH-G4.3 S-G.A15(4-3)
NetCH-G4_4: CH-G4.4 S-G.A16(4-4)
NetCH-G5_1: CH-G5.1 S-G.B13(5-1)
NetCH-G5_2: CH-G5.2 S-G.B14(5-2)
NetCH-G5_3: CH-G5.3 S-G.B15(5-3)
NetCH-G5_4: CH-G5.4 S-G.B16(5-4)
NetCH-G6_1: CH-G6.1 S-G.C13(6-1)
NetCH-G6_2: CH-G6.2 S-G.C14(6-2)
NetCH-G6_3: CH-G6.3 S-G.C15(6-3)
NetCH-G6_4: CH-G6.4 S-G.C16(6-4)
NetCH-G7_1: CH-G7.1 S-G.A17(7-1)
NetCH-G7_2: CH-G7.2 S-G.A18(7-2)
NetCH-G7_3: CH-G7.3 S-G.A19(7-3)
NetCH-G7_4: CH-G7.4 S-G.A20(7-4)
NetCH-G8_1: CH-G8.1 S-G.B17(8-1)
NetCH-G8_2: CH-G8.2 S-G.B18(8-2)
NetCH-G8_3: CH-G8.3 S-G.B19(8-3)
NetCH-G8_4: CH-G8.4 S-G.B20(8-4)
NetCH-G9_1: CH-G9.1 S-G.C17(9-1)
NetCH-G9_2: CH-G9.2 S-G.C18(9-2)
NetCH-G9_3: CH-G9.3 S-G.C19(9-3)
NetCH-G9_4: CH-G9.4 S-G.C20(9-4)
NetCH-H1_1: CH-H1.1 S-H.A9(1-1)
NetCH-H1_2: CH-H1.2 S-H.A10(1-2)
NetCH-H1_3: CH-H1.3 S-H.A11(1-3)
NetCH-H1_4: CH-H1.4 S-H.A12(1-4)
NetCH-H2_1: CH-H2.1 S-H.B9(2-1)
NetCH-H2_2: CH-H2.2 S-H.B10(2-2)
NetCH-H2_3: CH-H2.3 S-H.B11(2-3)
NetCH-H2_4: CH-H2.4 S-H.B12(2-4)
NetCH-H3_1: CH-H3.1 S-H.C9(3-1)
NetCH-H3_2: CH-H3.2 S-H.C10(3-2)
NetCH-H3_3: CH-H3.3 S-H.C11(3-3)
NetCH-H3_4: CH-H3.4 S-H.C12(3-4)
NetCH-H4_1: CH-H4.1 S-H.A13(4-1)
NetCH-H4_2: CH-H4.2 S-H.A14(4-2)
NetCH-H4_3: CH-H4.3 S-H.A15(4-3)
NetCH-H4_4: CH-H4.4 S-H.A16(4-4)
NetCH-H5_1: CH-H5.1 S-H.B13(5-1)
NetCH-H5_2: CH-H5.2 S-H.B14(5-2)
NetCH-H5_3: CH-H5.3 S-H.B15(5-3)
NetCH-H5_4: CH-H5.4 S-H.B16(5-4)
NetCH-H6_1: CH-H6.1 S-H.C13(6-1)
NetCH-H6_2: CH-H6.2 S-H.C14(6-2)
NetCH-H6_3: CH-H6.3 S-H.C15(6-3)
NetCH-H6_4: CH-H6.4 S-H.C16(6-4)
NetCH-H7_1: CH-H7.1 S-H.A17(7-1)
NetCH-H7_2: CH-H7.2 S-H.A18(7-2)
NetCH-H7_3: CH-H7.3 S-H.A19(7-3)
NetCH-H7_4: CH-H7.4 S-H.A20(7-4)
NetCH-H8_1: CH-H8.1 S-H.B17(8-1)
NetCH-H8_2: CH-H8.2 S-H.B18(8-2)
NetCH-H8_3: CH-H8.3 S-H.B19(8-3)
NetCH-H8_4: CH-H8.4 S-H.B20(8-4)
NetCH-H9_1: CH-H9.1 S-H.C17(9-1)
NetCH-H9_2: CH-H9.2 S-H.C18(9-2)
NetCH-H9_3: CH-H9.3 S-H.C19(9-3)
NetCH-H9_4: CH-H9.4 S-H.C20(9-4)
NetCH-I1_1: CH-I1.1 S-I.A9(1-1)
NetCH-I1_2: CH-I1.2 S-I.A10(1-2)
NetCH-I1_3: CH-I1.3 S-I.A11(1-3)
NetCH-I1_4: CH-I1.4 S-I.A12(1-4)
NetCH-I2_1: CH-I2.1 S-I.B9(2-1)
NetCH-I2_2: CH-I2.2 S-I.B10(2-2)
NetCH-I2_3: CH-I2.3 S-I.B11(2-3)
NetCH-I2_4: CH-I2.4 S-I.B12(2-4)
NetCH-I3_1: CH-I3.1 S-I.C9(3-1)
NetCH-I3_2: CH-I3.2 S-I.C10(3-2)
NetCH-I3_3: CH-I3.3 S-I.C11(3-3)
NetCH-I3_4: CH-I3.4 S-I.C12(3-4)
NetCH-I4_1: CH-I4.1 S-I.A13(4-1)
NetCH-I4_2: CH-I4.2 S-I.A14(4-2)
NetCH-I4_3: CH-I4.3 S-I.A15(4-3)
NetCH-I4_4: CH-I4.4 S-I.A16(4-4)
NetCH-I5_1: CH-I5.1 S-I.B13(5-1)
NetCH-I5_2: CH-I5.2 S-I.B14(5-2)
NetCH-I5_3: CH-I5.3 S-I.B15(5-3)
NetCH-I5_4: CH-I5.4 S-I.B16(5-4)
NetCH-I6_1: CH-I6.1 S-I.C13(6-1)
NetCH-I6_2: CH-I6.2 S-I.C14(6-2)
NetCH-I6_3: CH-I6.3 S-I.C15(6-3)
NetCH-I6_4: CH-I6.4 S-I.C16(6-4)
NetCH-I7_1: CH-I7.1 S-I.A17(7-1)
NetCH-I7_2: CH-I7.2 S-I.A18(7-2)
NetCH-I7_3: CH-I7.3 S-I.A19(7-3)
NetCH-I7_4: CH-I7.4 S-I.A20(7-4)
NetCH-I8_1: CH-I8.1 S-I.B17(8-1)
NetCH-I8_2: CH-I8.2 S-I.B18(8-2)
NetCH-I8_3: CH-I8.3 S-I.B19(8-3)
NetCH-I8_4: CH-I8.4 S-I.B20(8-4)
NetCH-I9_1: CH-I9.1 S-I.C17(9-1)
NetCH-I9_2: CH-I9.2 S-I.C18(9-2)
NetCH-I9_3: CH-I9.3 S-I.C19(9-3)
NetCH-I9_4: CH-I9.4 S-I.C20(9-4)
NetCH-J1_1: CH-J1.1 S-J.A9(1-1)
NetCH-J1_2: CH-J1.2 S-J.A10(1-2)
NetCH-J1_3: CH-J1.3 S-J.A11(1-3)
NetCH-J1_4: CH-J1.4 S-J.A12(1-4)
NetCH-J2_1: CH-J2.1 S-J.B9(2-1)
NetCH-J2_2: CH-J2.2 S-J.B10(2-2)
NetCH-J2_3: CH-J2.3 S-J.B11(2-3)
NetCH-J2_4: CH-J2.4 S-J.B12(2-4)
NetCH-J3_1: CH-J3.1 S-J.C9(3-1)
NetCH-J3_2: CH-J3.2 S-J.C10(3-2)
NetCH-J3_3: CH-J3.3 S-J.C11(3-3)
NetCH-J3_4: CH-J3.4 S-J.C12(3-4)
NetCH-J4_1: CH-J4.1 S-J.A13(4-1)
NetCH-J4_2: CH-J4.2 S-J.A14(4-2)
NetCH-J4_3: CH-J4.3 S-J.A15(4-3)
NetCH-J4_4: CH-J4.4 S-J.A16(4-4)
NetCH-J5_1: CH-J5.1 S-J.B13(5-1)
NetCH-J5_2: CH-J5.2 S-J.B14(5-2)
NetCH-J5_3: CH-J5.3 S-J.B15(5-3)
NetCH-J5_4: CH-J5.4 S-J.B16(5-4)
NetCH-J6_1: CH-J6.1 S-J.C13(6-1)
NetCH-J6_2: CH-J6.2 S-J.C14(6-2)
NetCH-J6_3: CH-J6.3 S-J.C15(6-3)
NetCH-J6_4: CH-J6.4 S-J.C16(6-4)
NetCH-J7_1: CH-J7.1 S-J.A17(7-1)
NetCH-J7_2: CH-J7.2 S-J.A18(7-2)
NetCH-J7_3: CH-J7.3 S-J.A19(7-3)
NetCH-J7_4: CH-J7.4 S-J.A20(7-4)
NetCH-J8_1: CH-J8.1 S-J.B17(8-1)
NetCH-J8_2: CH-J8.2 S-J.B18(8-2)
NetCH-J8_3: CH-J8.3 S-J.B19(8-3)
NetCH-J8_4: CH-J8.4 S-J.B20(8-4)
NetCH-J9_1: CH-J9.1 S-J.C17(9-1)
NetCH-J9_2: CH-J9.2 S-J.C18(9-2)
NetCH-J9_3: CH-J9.3 S-J.C19(9-3)
NetCH-J9_4: CH-J9.4 S-J.C20(9-4)
NetDISP1_1: DISP1.1(E) SR.3(QD)
NetDISP1_2: DISP1.2(D) SR.2(QC)
NetDISP1_4: DISP1.4(C) SR.1(QB)
NetDISP1_5: DISP1.5(DP) SR.15(QA)
NetDISP1_6: DISP1.6(B) SR.7(QH)
NetDISP1_7: DISP1.7(A) SR.6(QG)
NetDISP1_9: DISP1.9(F) SR.5(QF)
NetDISP1_10: DISP1.10(G) SR.4(QE)
NetHP-A_1: HP-A.1 HP-B.1 HP-C.1 HP-D.1 HP-E.1 HP-F.1 HP-G.1 HP-H.1 HP-I.1 HP-J.1 HP-MAIN.1 PWR_INPUT.B S-A.HPIB S-B.HPIB S-C.HPIB S-D.HPIB S-E.HPIB S-F.HPIB S-G.HPIB S-H.HPIB S-I.HPIB
NetOSA_A: OSA.A S-A.HPOA
NetOSA_B: OSA.B S-A.HPOB
NetOSB_A: OSB.A S-B.HPOA
NetOSB_B: OSB.B S-B.HPOB
NetOSC_A: OSC.A S-C.HPOA
NetOSC_B: OSC.B S-C.HPOB
NetOSD_A: OSD.A S-D.HPOA
NetOSD_B: OSD.B S-D.HPOB
NetOSE_A: OSE.A S-E.HPOA
NetOSE_B: OSE.B S-E.HPOB
NetOSF_A: OSF.A S-F.HPOA
NetOSF_B: OSF.B S-F.HPOB
NetOSG_A: OSG.A S-G.HPOA
NetOSG_B: OSG.B S-G.HPOB
NetOSH_A: OSH.A S-H.HPOA
NetOSH_B: OSH.B S-H.HPOB
NetOSI_A: OSI.A S-I.HPOA
NetOSI_B: OSI.B S-I.HPOB
NetOSJ_A: OSJ.A S-J.HPOA
NetOSJ_B: OSJ.B S-J.HPOB
NetRPI_3: RPI.3(GPIO_02_(SDA1,I2C)) S-A.C7(SDA2) S-B.C7(SDA2) S-C.C7(SDA2) S-D.C7(SDA2) S-E.C7(SDA2) S-F.C7(SDA2) S-G.C7(SDA2) S-H.C7(SDA2) S-I.C7(SDA2) S-J.C7(SDA2)
NetRPI_5: RPI.5(GPIO_03_(SCL1,I2C)) S-A.C6(SLC2) S-B.C6(SLC2) S-C.C6(SLC2) S-D.C6(SLC2) S-E.C6(SLC2) S-F.C6(SLC2) S-G.C6(SLC2) S-H.C6(SLC2) S-I.C6(SLC2) S-J.C6(SLC2)
NetRPI_15: RPI.15(GPIO_22_(GPIO_GEN3)) S-G.B5(RPI)
NetRPI_29: RPI.29(GPIO_05) S-H.B5(RPI)
